# Altium schematic sheet Cell_Overhead.SchDoc
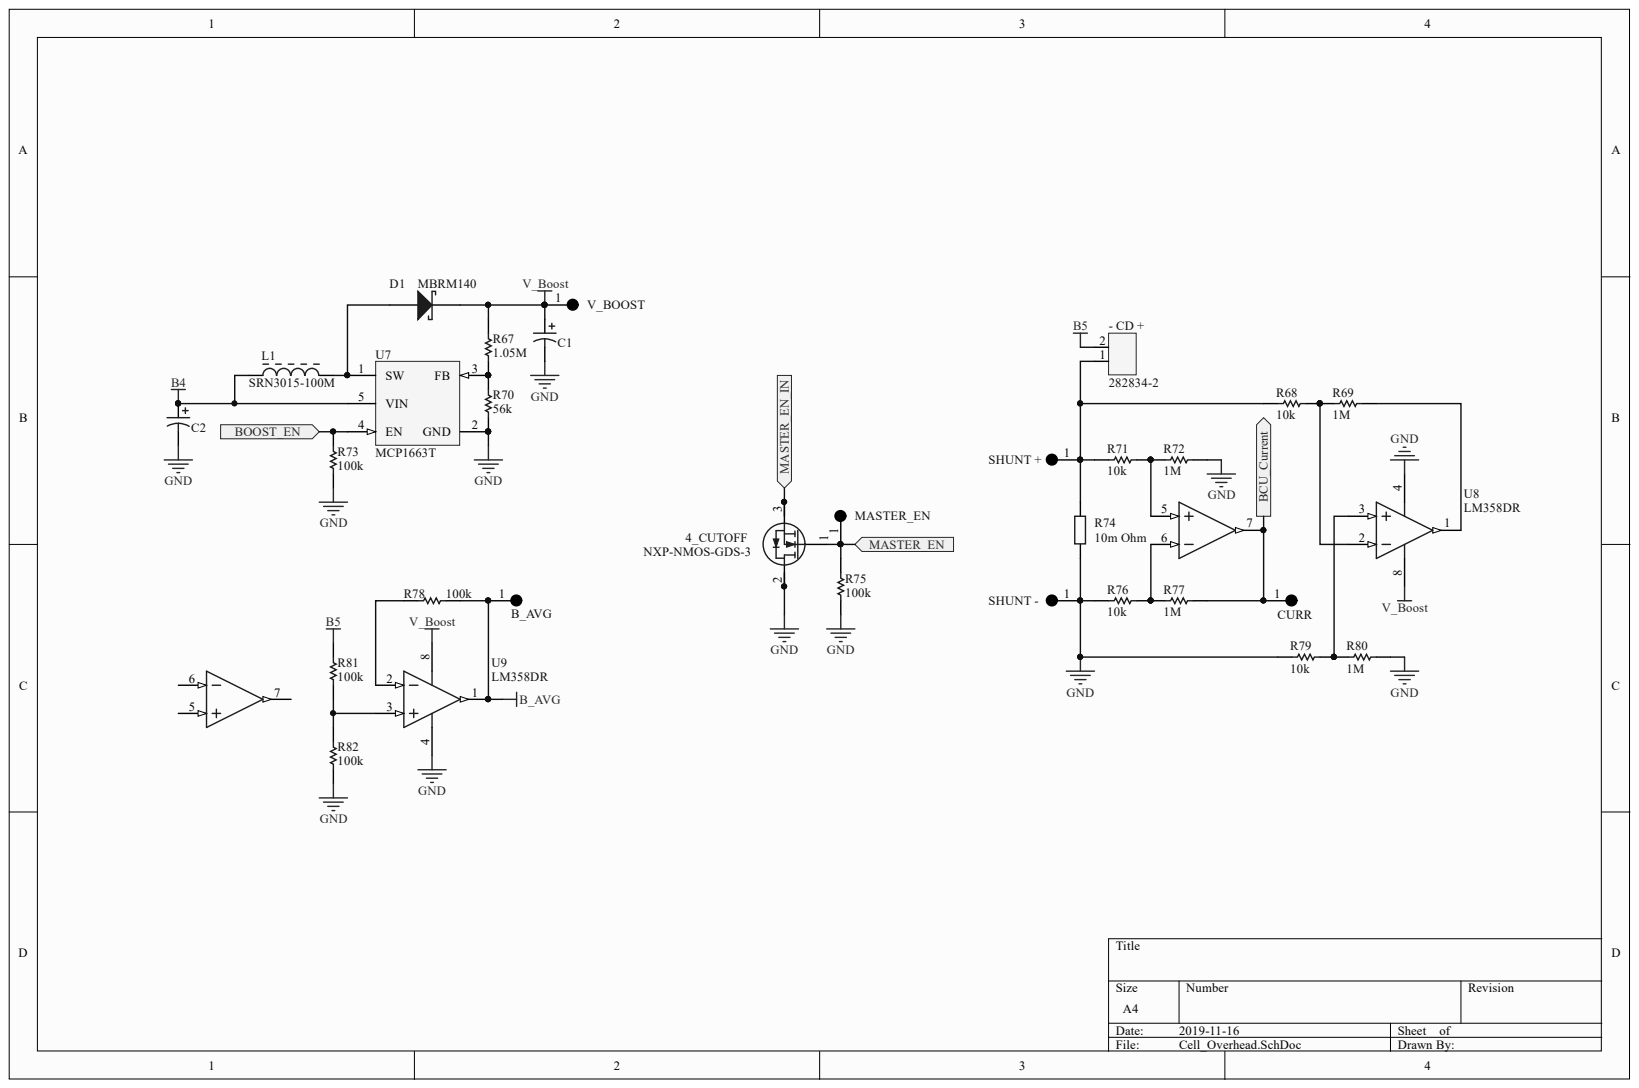
[diagram p0: Cell_Overhead.SchDoc]

## Parts
4_CUTOFF  NXP-NMOS-GDS-3  [PCB-oiskee3ochvx8romhs33-1]
-_CD_+  282834-2  [PCB-6xrngco598u3mra2uzi2-1]
B_AVG  Keystone 5011  [PCB-nje03k9v44gt2snsz3w9-1]  (value not drawn)
C1  Cap Pol2  [Capacitor]  (value not drawn)
C2  Cap Pol2  [Capacitor]  (value not drawn)
CURR  Keystone 5011  [PCB-nje03k9v44gt2snsz3w9-1]  (value not drawn)
D1  MBRM140  [ONSC-POWERMITE-2-457-04_D_V]
L1  SRN3015-100M  [BOUR-SRN3015-2_V]
MASTER_EN  Keystone 5011  [PCB-nje03k9v44gt2snsz3w9-1]  (value not drawn)
R67  1.05M  [PCB-x6wf4w6j5g6utc0o2uwl-1]
R68  10k  [PCB-aqoxr6ml32k4tyzn59x5-1]
R69  1M  [PCB-jj69kinef8gb32px5x6z-1]
R70  56k  [PCB-4q0kzc7h4h9dhbvzvdws-1]
R71  10k  [PCB-aqoxr6ml32k4tyzn59x5-1]
R72  1M  [PCB-jj69kinef8gb32px5x6z-1]
R73  100k  [PCB-8m9rktjyp1k274dv6t06-1]
R74  10m Ohm  [PCB-29qdkmyqfzxb3rbkb4hf-1]
R75  100k  [PCB-8m9rktjyp1k274dv6t06-1]
R76  10k  [PCB-aqoxr6ml32k4tyzn59x5-1]
R77  1M  [PCB-jj69kinef8gb32px5x6z-1]
R78  100k  [PCB-log9y0wuy6o5jvxe2v7d-1]
R79  10k  [PCB-aqoxr6ml32k4tyzn59x5-1]
R80  1M  [PCB-jj69kinef8gb32px5x6z-1]
R81  100k  [PCB-log9y0wuy6o5jvxe2v7d-1]
R82  100k  [PCB-log9y0wuy6o5jvxe2v7d-1]
SHUNT_+  Keystone 5011  [PCB-nje03k9v44gt2snsz3w9-1]  (value not drawn)
SHUNT_-  Keystone 5011  [PCB-nje03k9v44gt2snsz3w9-1]  (value not drawn)
U7  MCP1663T  [PCB-9rpajw45wcaob5ocqz54-1]
U8  LM358DR  [PCB-5j1ieh3zn6lddoji8et2-1]
U9  LM358DR  [PCB-5j1ieh3zn6lddoji8et2-1]
V_BOOST  Keystone 5011  [PCB-nje03k9v44gt2snsz3w9-1]  (value not drawn)

## Ports
BCU_CURRENT, BOOST_EN, MASTER_EN, MASTER_EN_IN

## Nets
B4: C2.1 L1.1 U7.5(VIN)
B5: -_CD_+.2 R81.1
B_AVG: B_AVG.1 R78.2 U9.1
GND: 4_CUTOFF.2 C1.2 C2.2 R70.1 R72.2 R73.2 R74.1 R75.2 R76.1 R79.1 R80.2 R82.2 SHUNT_-.1 U7.2(GND) U8.4 U9.4
V_BOOST: C1.1 D1.1 R67.2 U8.8 U9.8 V_BOOST.1
BOOST_EN: R73.1 U7.4(EN) ; port BOOST_EN
MASTER_EN: 4_CUTOFF.1 MASTER_EN.1 R75.1 ; port MASTER_EN
MASTER_EN_IN: 4_CUTOFF.3 ; port MASTER_EN_IN
Net- CD +_1: -_CD_+.1 R68.1 R71.1 R74.2 SHUNT_+.1
NetCURR_1: CURR.1 R77.2 U8.7 ; port BCU_CURRENT
NetD1_2: D1.2 L1.2 U7.1(SW)
NetR67_1: R67.1 R70.2 U7.3(FB)
NetR68_2: R68.2 R69.1 U8.2
NetR69_2: R69.2 U8.1
NetR71_2: R71.2 R72.1 U8.5
NetR76_2: R76.2 R77.1 U8.6
NetR78_1: R78.1 U9.2
NetR79_2: R79.2 R80.1 U8.3
NetR81_2: R81.2 R82.1 U9.3

Not connected: U9 5 6 7

## Library data of the parts
4_CUTOFF = CMP-829872-4: N-Channel Logic Level MOSFET, 30 V, 30 A, 3-Pin SOT78, Tube; Manufacturer: NXP Semiconductors; Pakcage: TO-220; Rated Voltage (Vdss): 30 V; Component Kind: Standard; Library Name: CommunityVault; Lifecycle Status: Active; Number of Pins: 3; Octopart Url: https://octopart.com/psmn022-30pl%2C127-nxp+semiconductors-18837202; Polarity: N-Channel
-_CD_+ = 282834-2: Wire-To-Board Terminal Block, 2, 150 V, 10 A, 30 Awg, 16 Awg, 1.4 Mm; ELV Compliant: Compliant; Lead-Free Status: Lead Free; Number of Contacts: 2; Wire Gauge: 16.0 AWG to 30.0 AWG; Color: Green; Component Kind: Standard; Contact Material: Brass; Contact Plating: Tin; Flammability Rating: UL 94 V-0; Library Name: Octopart library; Mounting Style: Screw, Through Hole; Octopart URL: https://octopart.com/282834-2-te+connectivity-46506870; RoHS: Compliant; Size-Width: 6.50 mm
B_AVG CURR MASTER_EN SHUNT_+ SHUNT_- V_BOOST = 5011: Test Point; Black; Thru-Hole; Snap-Fit; SilverPlate; 0.063in. Dia.; 0.445In.; Component Kind: Net Tie; Library Name: Octopart library
C1 C2 = CMP-2e19e5496191f1de-3: Electrolytic capacitor Radial lead 2 mm 10 µF 50 V 20 % (Ø) 5 mm; Manufacturer Part Number: ECE-A1HKA100; Capacitance: 10.0 µF; Lead-Free Status: Lead Free; Component Kind: Standard; Library Name: CommunityVault; Mounting Style: Through Hole; OctopartID: 2e19e5496191f1de; RoHS: Compliant; Size-Diameter: 5.00 mm; Size-Height: 7.00 mm
D1 = CMP-816670-3: Schottky Power Rectifier, 2-Pin Powermite, Pb-Free, Tape and Reel; Manufacturer Part Number: MBRM140T3G; Current Rating: 1.0 A; Forward Voltage: 0.55 V; Lead-Free Status: Lead Free; Polarity: Standard; Voltage Rating (DC): 40.0 V; Case/Package: POWERMITE; Component Kind: Standard; Halogen Free Status: Halogen Free; Library Name: CommunityVault; Lifecycle Status: Active; Mounting Style: Surface Mount; Number of Pins: 2; OctopartID: 9e3a5735b61b41fd; Octopart Url: https://octopart.com/mbrm140t3g-on+semiconductor-331079; Packaging: Cut Tape (CT); REACH SVHC Compliance: No SVHC; RoHS: Compliant
L1 = CMP-911278-2: Semi-shielded Power Inductor, 10 uH, +/- 20%, 1 A, -40 to 125 degC, 3 x 3 x 1.5 mm SMD, RoHS and Halogen Free, Tape and Reel; Inductance: 1e-05 H; Self Resonant Frequency: 45000000.0 Hz; Component Kind: Standard; Library Name: CommunityVault; Manufacturer Part Number: SRN3015-100M; Number of Pins: 2; OctopartID: 45668d5663779aa1; Octopart Url: https://octopart.com/srn3015-100m-bourns-20579191; Packaging: Tape & Reel (TR); REACH SVHC Compliance: No SVHC; RoHS: Compliant
R67 = CMP-4fbb84ee6648907c-1: Res Thick Film 0603 1.05M Ohm 1% 0.1W(1/10W) ±100ppm/C Pad SMD Automotive T/R; Manufacturer Part Number: CRCW06031M05FKEA; Case/Package (SI): 1608; Lead-Free Status: Lead Free; Power Rating: 100 mW; Resistance: 1.05 M?; Temperature Coefficient: -100 ppm/°C to 100 ppm/°C; Voltage Rating (DC): 75.0 V; Case/Package: 0603; Component Kind: Standard; Library Name: FavoritesLibrary; Mounting Style: Surface Mount; Number of Pins: 2; OctopartID: 4fbb84ee6648907c; Octopart URL: https://octopart.com/crcw06031m05fkea-vishay-39783573; Operating Temperature: -55.0 °C to 150 °C; Packaging: Cut Tape (CT), Reel, Tape & Reel (TR); REACH SVHC Compliance: No SVHC; Resistance Tolerance: ±1%; RoHS: Compliant; Size-Height: 450 µm; Size-Length: 1.55 mm; Size-Width: 850 µm
R68 R71 R76 R79 = CMP-3716937-3: Res Thin Film 0603 10K Ohm 0.1% 1/10W ±25ppm/°C Molded SMD SMD Punched Carrier T/R; Manufacturer Part Number: ERA-3AEB103V; Case/Package (SI): 1608; Lead-Free Status: Lead Free; Power Rating: 100 mW; Resistance: 10.0 k?; Temperature Coefficient: -25.0 ppm/°C to 25.0 ppm/°C; Voltage Rating (DC): 75.0 V; Case/Package: 0603; Component Kind: Standard; Library Name: FavoritesLibrary; Lifecycle Status: Not Listed by Manufacturer; Mounting Style: Surface Mount; Number of Pins: 2; OctopartID: 7fecb5ab3967a02b; Octopart URL: https://octopart.com/era-3aeb103v-panasonic-55403501; Operating Temperature: 155 °C (max); Packaging: Cut Tape (CT), Reel, Tape & Reel (TR); REACH SVHC Compliance: No SVHC; Resistance Tolerance: ±0.1%; RoHS: Compliant; Size-Height: 450 µm; Size-Length: 1.60 mm; Size-Width: 800 µm
R69 R72 R77 R80 = CMP-36eefeb38e66e929-2: Res Thin Film 0603 1M Ohm 0.1% 1/16W ±25ppm/°C Molded SMD SMD Paper T/R; Manufacturer Part Number: CPF0603B1M0E1; Case/Package (SI): 1608; ELV Compliant: Compliant; Lead-Free Status: Lead Free; Power Rating: 63.0 mW; Resistance: 1.00 M?; Temperature Coefficient: -25.0 ppm/°C to 25.0 ppm/°C; Voltage Rating (DC): 50.0 V; Case/Package: 1608, 0603; Component Kind: Standard; Library Name: FavoritesLibrary; Lifecycle Status: Active; Mounting Style: Surface Mount; OctopartID: 36eefeb38e66e929; Octopart URL: https://octopart.com/cpf0603b1m0e1-te+connectivity-22519278; Packaging: Tape & Reel (TR); REACH SVHC Compliance: No SVHC; Resistance Tolerance: ±0.1%; RoHS: Compliant
R70 = CMP-b0827bf01b726f45-2: Res Thick Film 0603 56K Ohm 1% 0.1W(1/10W) ±100ppm/C Pad SMD T/R; Manufacturer Part Number: RC0603FR-0756KL; Case/Package (SI): 1608; Lead-Free Status: Lead Free; Power Rating: 100 mW; Resistance: 56.0 k?; Temperature Coefficient: -100 ppm/°C to 100 ppm/°C; Voltage Rating (DC): 75.0 V, 50.0 V; Case/Package: 0603; Component Kind: Standard; Library Name: FavoritesLibrary; Mounting Style: Surface Mount; Number of Pins: 2; OctopartID: b0827bf01b726f45; Octopart URL: https://octopart.com/rc0603fr-0756kl-yageo-41455254; Operating Temperature: 155 °C (max); Packaging: Tape & Reel; REACH SVHC Compliance: No SVHC; Resistance Tolerance: ±1%; RoHS: Compliant
R73 R75 = CMP-d96115072f6806a6-1: Res, Auto, Thick Film, 100K, 5%, 0603; Component Kind: Standard; Library Name: CommunityVault; Lifecycle Status: Not Listed by Manufacturer
R74 = CMP-af9863824c16705f-1: Res Ww Current Sense Sr3 0.01 1% E66 E3 Axl Th Rohs Compliant: Yes; Manufacturer Part Number: SR3R0100FE66; Component Kind: Standard; Library Name: FavoritesLibrary; OctopartID: af9863824c16705f; Octopart URL: https://octopart.com/sr3r0100fe66-vishay-84985576; RoHS: Compliant
R78 R81 R82 = CMP-bnjbs9wz50zo2virqymb-1: RES SMD 100K OHM 0.1% 1/5W 0603; Component Kind: Standard; CustomComponentID: bnjbs9wz50zo2virqymb; Library Name: FavoritesLibrary
U7 = CMP-0cd5c6a628e2d312-1: 32V Output; 1.8A Switch; Non-synchronous Boost Regulator in SOT235 SOT-23T/R; Manufacturer Part Number: MCP1663T-E/OT; Lead-Free Status: Lead Free; Number of Outputs: 1; Output Current: 375 mA; Output Voltage: 32.0 V (max); Case/Package: SOT-23; Component Kind: Standard; Library Name: FavoritesLibrary; Lifecycle Status: Not Listed by Manufacturer; Mounting Style: Surface Mount; Number of Pins: 5; OctopartID: 0cd5c6a628e2d312; Octopart URL: https://octopart.com/mcp1663t-e%2Fot-microchip-58261841; Operating Temperature: 125 °C (max); Packaging: Tape & Reel (TR); RoHS: Compliant
U8 U9 = CMP-4gajgemt6408qmd47hs9-2: 8-SOIC Dual Op-Amp; Component Kind: Standard; CustomComponentID: 4gajgemt6408qmd47hs9; Library Name: FavoritesLibrary
